# Altium schematic sheet 419-005-01-02_PG 14 - Wireless.SchDoc("419-005-01-02_PG 14 - Wireless")
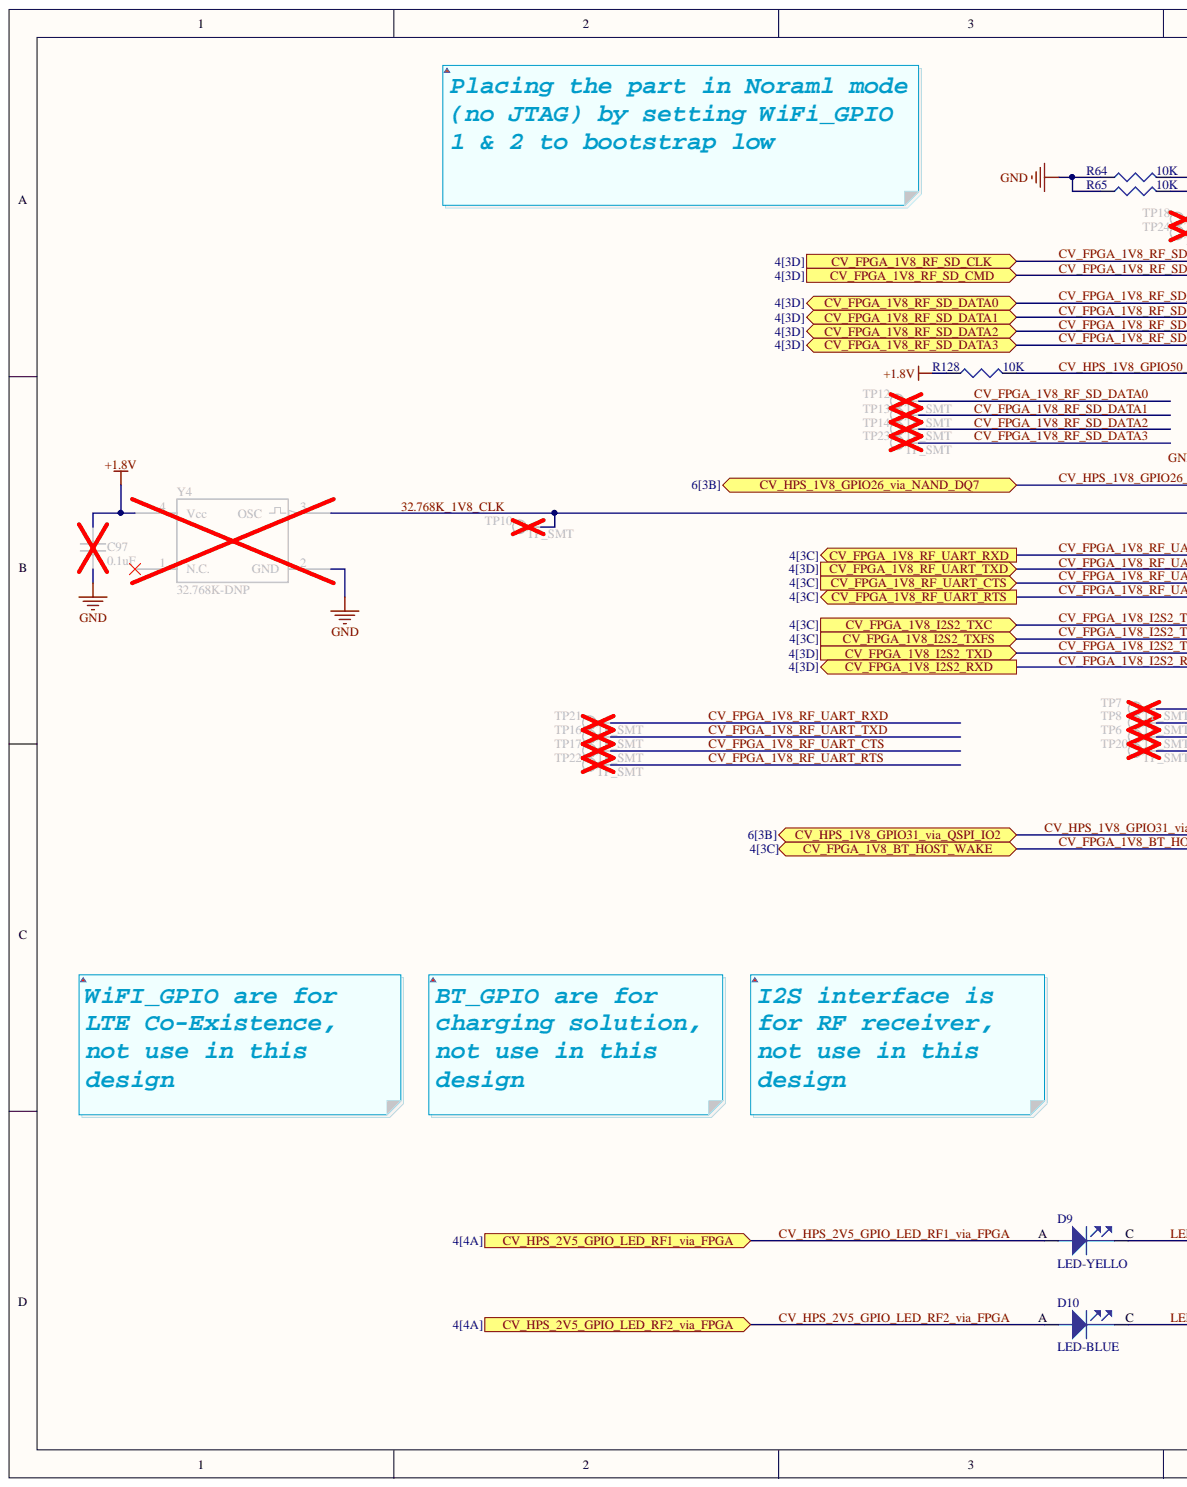
[diagram p0: 419-005-01-02_PG 14 - Wireless.SchDoc, left]
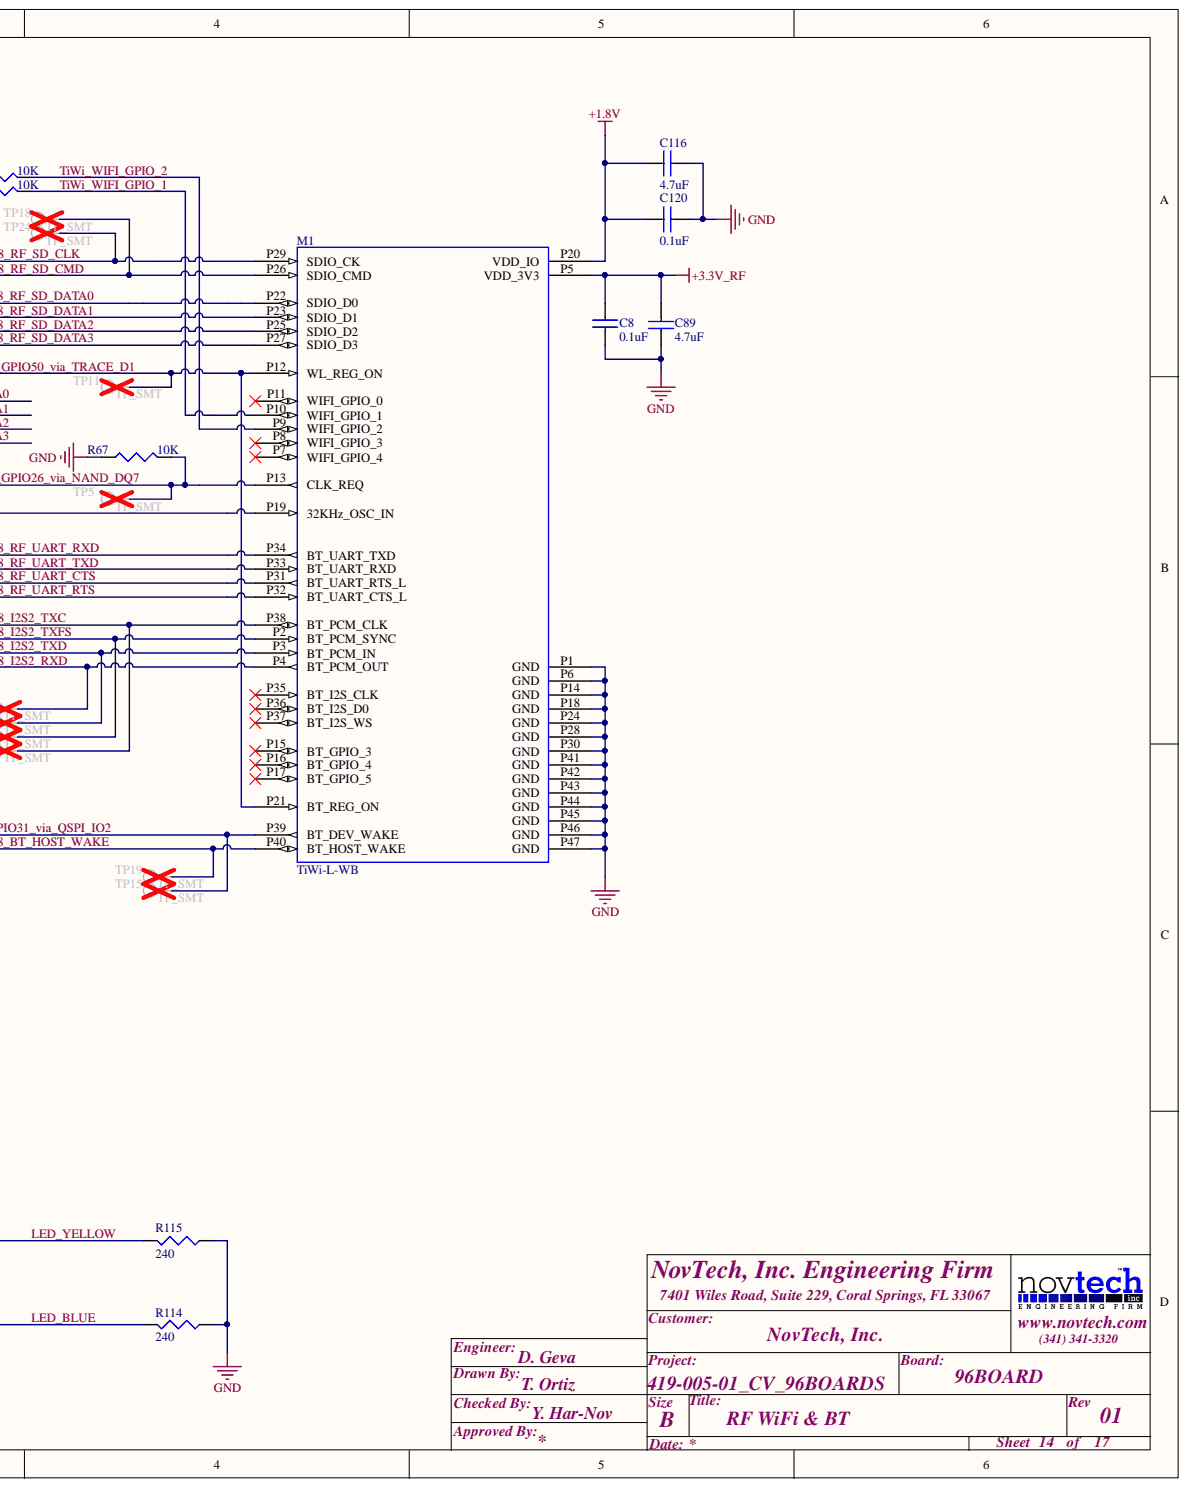
[diagram p1: 419-005-01-02_PG 14 - Wireless.SchDoc, right]

## Parts
C8  0.1uF  [0201_C]
C89  4.7uF  [0402_C]
C97  0.1uF  [0201_C]
C116  4.7uF  [0402_C]
C120  0.1uF  [0201_C]
D9  LED-YELLO  [0603_LED]
D10  LED-BLUE  [0603_LED]
M1  TiWi-L-WB  [TiWi-L-WB]
R64  10K  [0201_R]
R65  10K  [0201_R]
R67  10K  [0201_R]
R114  240  [0201_R]
R115  240  [0201_R]
R128  10K  [0201_R]
TP5  TP_SMT  [TEST_POINT_SMT]
TP6  TP_SMT  [TEST_POINT_SMT]
TP7  TP_SMT  [TEST_POINT_SMT]
TP8  TP_SMT  [TEST_POINT_SMT]
TP10  TP_SMT  [TEST_POINT_SMT]
TP11  TP_SMT  [TEST_POINT_SMT]
TP12  TP_SMT  [TEST_POINT_SMT]
TP13  TP_SMT  [TEST_POINT_SMT]
TP14  TP_SMT  [TEST_POINT_SMT]
TP15  TP_SMT  [TEST_POINT_SMT]
TP16  TP_SMT  [TEST_POINT_SMT]
TP17  TP_SMT  [TEST_POINT_SMT]
TP18  TP_SMT  [TEST_POINT_SMT]
TP19  TP_SMT  [TEST_POINT_SMT]
TP20  TP_SMT  [TEST_POINT_SMT]
TP21  TP_SMT  [TEST_POINT_SMT]
TP22  TP_SMT  [TEST_POINT_SMT]
TP23  TP_SMT  [TEST_POINT_SMT]
TP24  TP_SMT  [TEST_POINT_SMT]
Y4  32.768K-DNP  [OSC_SMT_2.0X1.2]

## Ports
CV_FPGA_1V8_BT_HOST_WAKE, CV_FPGA_1V8_I2S2_RXD, CV_FPGA_1V8_I2S2_TXC, CV_FPGA_1V8_I2S2_TXD, CV_FPGA_1V8_I2S2_TXFS, CV_FPGA_1V8_RF_SD_CLK, CV_FPGA_1V8_RF_SD_CMD, CV_FPGA_1V8_RF_SD_DATA0, CV_FPGA_1V8_RF_SD_DATA1, CV_FPGA_1V8_RF_SD_DATA2, CV_FPGA_1V8_RF_SD_DATA3, CV_FPGA_1V8_RF_UART_CTS, CV_FPGA_1V8_RF_UART_RTS, CV_FPGA_1V8_RF_UART_RXD, CV_FPGA_1V8_RF_UART_TXD, CV_HPS_1V8_GPIO26_VIA_NAND_DQ7, CV_HPS_1V8_GPIO31_VIA_QSPI_IO2, CV_HPS_2V5_GPIO_LED_RF1_VIA_FPGA, CV_HPS_2V5_GPIO_LED_RF2_VIA_FPGA

## Nets
+1.8V: C97.1 C116.1 C120.1 M1.P20(VDD_IO) R128.1 Y4.4(Vcc)
+3.3V_RF: C8.1 C89.2 M1.P5(VDD_3V3)
GND: C8.2 C89.1 C97.2 C116.2 C120.2 M1.P1(GND) M1.P6(GND) M1.P14(GND) M1.P18(GND) M1.P24(GND) M1.P28(GND) M1.P30(GND) M1.P41(GND) M1.P42(GND) M1.P43(GND) M1.P44(GND) M1.P45(GND) M1.P46(GND) M1.P47(GND) R64.1 R65.1 R67.1 R114.1 R115.1 Y4.2(GND)
32.768K_1V8_CLK: M1.P19(32KHz_OSC_IN) TP10.1 Y4.3
CV_FPGA_1V8_BT_HOST_WAKE: M1.P40(BT_HOST_WAKE) TP19.1 ; port CV_FPGA_1V8_BT_HOST_WAKE
CV_FPGA_1V8_I2S2_RXD: M1.P4(BT_PCM_OUT) TP7.1 ; port CV_FPGA_1V8_I2S2_RXD
CV_FPGA_1V8_I2S2_TXC: M1.P38(BT_PCM_CLK) TP20.1 ; port CV_FPGA_1V8_I2S2_TXC
CV_FPGA_1V8_I2S2_TXD: M1.P3(BT_PCM_IN) TP8.1 ; port CV_FPGA_1V8_I2S2_TXD
CV_FPGA_1V8_I2S2_TXFS: M1.P2(BT_PCM_SYNC) TP6.1 ; port CV_FPGA_1V8_I2S2_TXFS
CV_FPGA_1V8_RF_SD_CLK: M1.P29(SDIO_CK) TP24.1 ; port CV_FPGA_1V8_RF_SD_CLK
CV_FPGA_1V8_RF_SD_CMD: M1.P26(SDIO_CMD) TP18.1 ; port CV_FPGA_1V8_RF_SD_CMD
CV_FPGA_1V8_RF_SD_DATA0: M1.P22(SDIO_D0) TP12.1 ; port CV_FPGA_1V8_RF_SD_DATA0
CV_FPGA_1V8_RF_SD_DATA1: M1.P23(SDIO_D1) TP13.1 ; port CV_FPGA_1V8_RF_SD_DATA1
CV_FPGA_1V8_RF_SD_DATA2: M1.P25(SDIO_D2) TP14.1 ; port CV_FPGA_1V8_RF_SD_DATA2
CV_FPGA_1V8_RF_SD_DATA3: M1.P27(SDIO_D3) TP23.1 ; port CV_FPGA_1V8_RF_SD_DATA3
CV_FPGA_1V8_RF_UART_CTS: M1.P31(BT_UART_RTS_L) TP17.1 ; port CV_FPGA_1V8_RF_UART_CTS
CV_FPGA_1V8_RF_UART_RTS: M1.P32(BT_UART_CTS_L) TP22.1 ; port CV_FPGA_1V8_RF_UART_RTS
CV_FPGA_1V8_RF_UART_RXD: M1.P34(BT_UART_TXD) TP21.1 ; port CV_FPGA_1V8_RF_UART_RXD
CV_FPGA_1V8_RF_UART_TXD: M1.P33(BT_UART_RXD) TP16.1 ; port CV_FPGA_1V8_RF_UART_TXD
CV_HPS_1V8_GPIO26_VIA_NAND_DQ7: M1.P13(CLK_REQ) R67.2 TP5.1 ; port CV_HPS_1V8_GPIO26_VIA_NAND_DQ7
CV_HPS_1V8_GPIO31_VIA_QSPI_IO2: M1.P39(BT_DEV_WAKE) TP15.1 ; port CV_HPS_1V8_GPIO31_VIA_QSPI_IO2
CV_HPS_1V8_GPIO50_VIA_TRACE_D1: M1.P12(WL_REG_ON) M1.P21(BT_REG_ON) R128.2 TP11.1
CV_HPS_2V5_GPIO_LED_RF1_VIA_FPGA: D9.A ; port CV_HPS_2V5_GPIO_LED_RF1_VIA_FPGA
CV_HPS_2V5_GPIO_LED_RF2_VIA_FPGA: D10.A ; port CV_HPS_2V5_GPIO_LED_RF2_VIA_FPGA
LED_BLUE: D10.C R114.2
LED_YELLOW: D9.C R115.2
TIWI_WIFI_GPIO_1: M1.P10(WIFI_GPIO_1) R65.2
TIWI_WIFI_GPIO_2: M1.P9(WIFI_GPIO_2) R64.2

Not connected: M1 P7(WIFI_GPIO_4) P8(WIFI_GPIO_3) P11(WIFI_GPIO_0) P15(BT_GPIO_3) P16(BT_GPIO_4) P17(BT_GPIO_5) P35(BT_I2S_CLK) P36(BT_I2S_D0) P37(BT_I2S_WS); Y4 1(N.C.)

## Library data of the parts
C8 C97 C120 = CAP_0201: Capasitor 0201 footprint; Component Kind: Standard; Library Name: PASSIVES.SchLib
C89 C116 = CAP_0402: Capasitor 0402 footprint; Bottom: 10; Right: 20; Component Kind: Standard; Library Name: PASSIVES.SchLib
D9 D10 = LED: Bottom: 20; Right: 40; Component Kind: Standard; Library Name: PASSIVES.SchLib
M1 = TiWi-L-WB: PART: 450-0125; Component Kind: Standard; Library Name: MODULES_SchLib.SCHLIB
R64 R65 R67 R114 R115 R128 = RESISOTR 0201: Resistor 0201 footprint; PART: ERJ-1GEF1002C; MFG: Panasonic; Component Kind: Standard; Digikey: P10.0KABCT-ND; Library Name: PASSIVES.SchLib; RoHS: Yes; TYPE: SMT
TP5-TP8 TP10-TP24 = TEST_POINT: PCB Test Point; Component Kind: Standard; Library Name: PASSIVES.SchLib
Y4 = OSCILLATOR: PART: SIT1533AI-H4-DCC-32.768E; MFG: SiTIME; TEMP: -40°C to +85°; Component Kind: Standard; DigiKey: 1473-1239-1-ND; Library Name: PASSIVES.SchLib; RoHS: Yes; TYPE: SMT
